# Altium schematic sheet Sheet4.SchDoc(Sheet4)
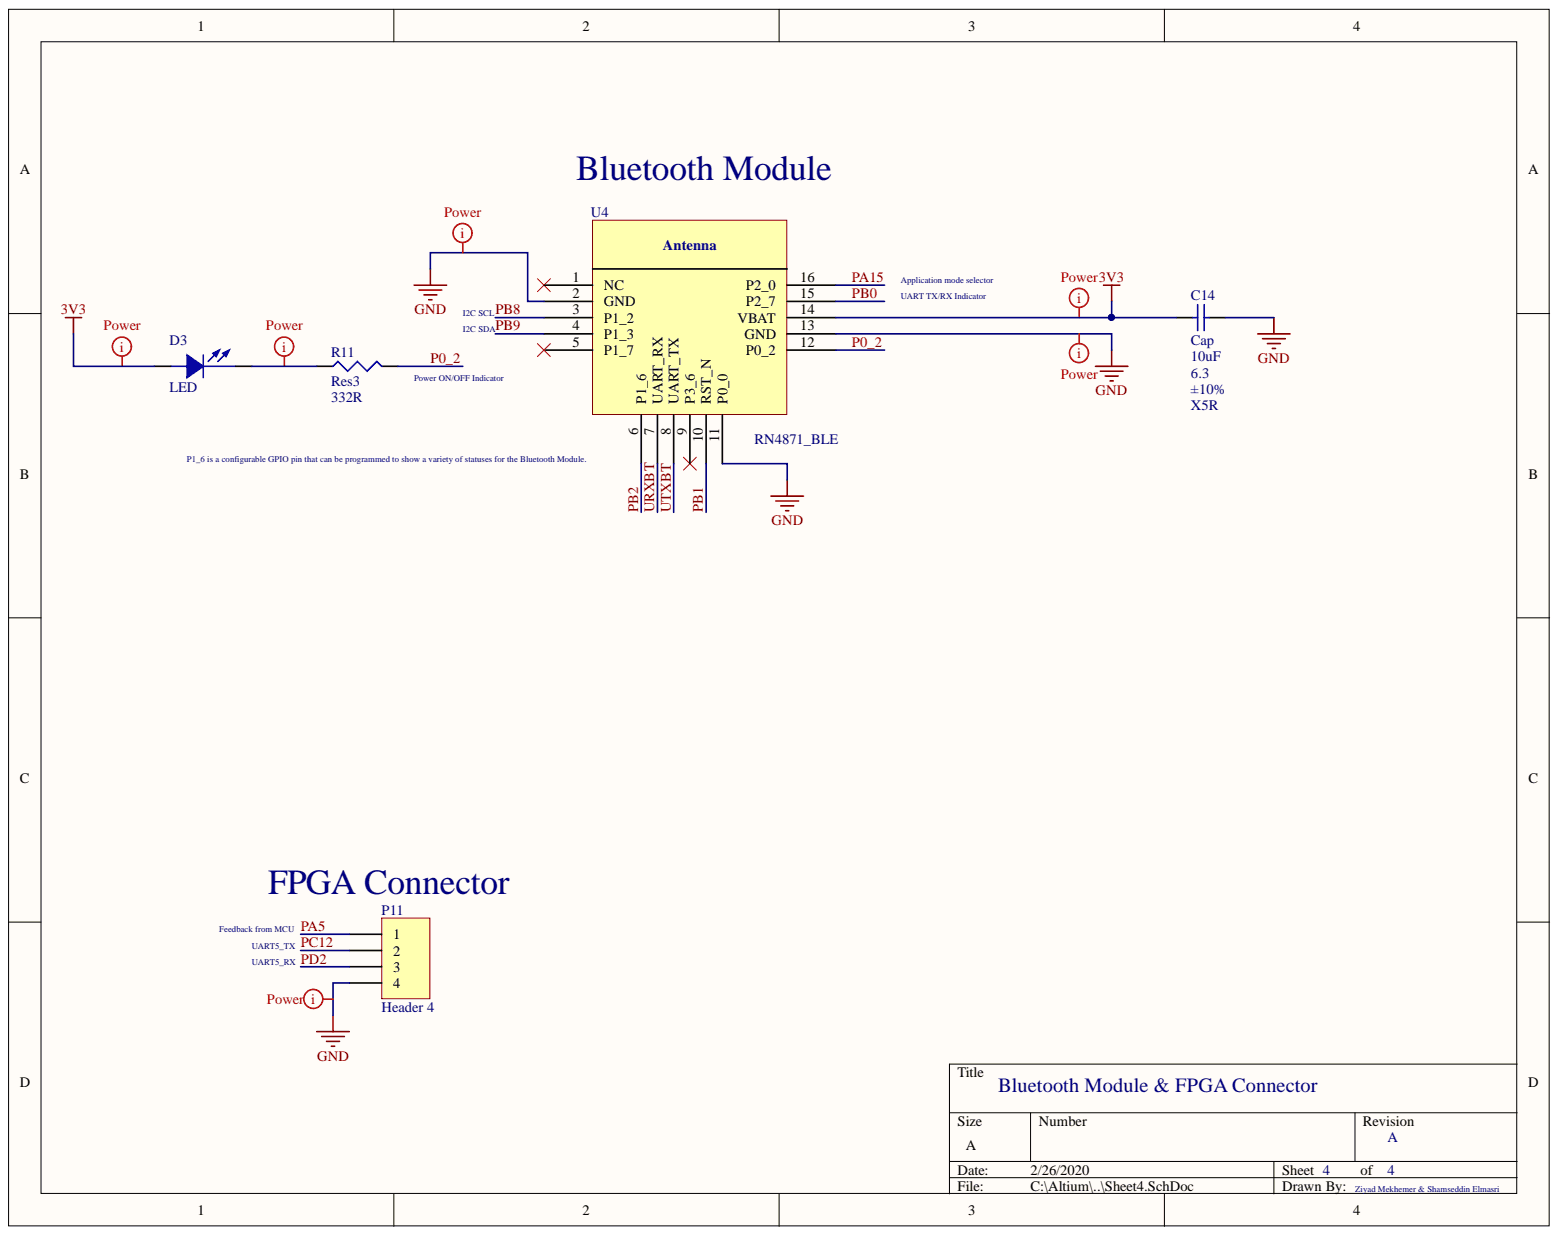
[diagram p0: Sheet4.SchDoc]

## Parts
C14  Cap  value 10uF  [CAPC2012X09M]
D3  LED  [LED]
P11  Header 4  [HDR1X4]
R11  Res3  value 332R  [RESC2112X05M]
U4  RN4871_BLE  [RN4871_BLE]

## Nets
3V3: C14.1 D3.1 U4.14(VBAT)
GND: C14.2 P11.4 U4.2(GND) U4.11(P0_0) U4.13(GND)
P0_2: R11.2 U4.12(P0_2)
PA5: P11.1
PA15: U4.16(P2_0)
PB0: U4.15(P2_7)
PB1: U4.10(RST_N)
PB2: U4.6(P1_6)
PB8: U4.3(P1_2)
PB9: U4.4(P1_3)
PC12: P11.2
PD2: P11.3
URXBT: U4.7(UART_RX)
UTXBT: U4.8(UART_TX)
NetD3_2: D3.2 R11.1

Not connected: U4 1(NC) 5(P1_7) 9(P3_6)

## Library data of the parts
C14 = CAP-X5R-10uF-6.3V-±10%: CAP CER 10uF 6.3v X5R 0805; MPN: C0805C106K9PACTU; WV: 6.3; Tolerance: ±10%; PN: 399-3138-1-ND; URL: http://www.digikey.ca/product-detail/en/C0805C106K9PACTU/399-3138-1-ND; Type: X5R; Name: CAP-X5R-10uF-6.3V-±10%; Library Name: esd_library.IntLib; Component Kind: Standard
P11 = Header 4: Header, 4-Pin Male; MPN: PEC04SFAN; PN: S1212E-04-ND; URL: http://www.digikey.ca/product-detail/en/PEC04SFAN/S1212E-04-ND; Library Name: esd_library.IntLib; Component Kind: Standard
R11 = Resistor_332R: RES SMD 332R OHM 1% 1/8W 0805; MPN: CRCW0805332RFKEA; PN: 541-332CCT-ND; URL: http://www.digikey.ca/product-detail/en/CRCW0805332RFKEA/541-332CCT-ND; Name: Resistor_332R; Library Name: esd_library.IntLib; Component Kind: Standard
U4 = RN4871_BLE: RN4870/71 BLE module by Microchip; Library Name: BT_Components.SchLib; ComponentLink3URL: http://ww1.microchip.com/downloads/en/DeviceDoc/RN4870-71-Bluetooth-Low-Energy-Module-User-Guide-DS50002466C.pdf; ComponentLink3Description: UserGuide; ComponentLink2URL: http://ww1.microchip.com/downloads/en/DeviceDoc/RN4870-71-Bluetooth-Low-Energy-Module-Data-Sheet-DS50002489D.pdf; ComponentLink2Description: Datasheet; ComponentLink1URL: https://www.digikey.ca/product-detail/en/microchip-technology/RN4871-I%2FRM128/RN4871-I%2FRM128-ND/8037765?utm_adgroup=RF%20Transceiver%20Modules&utm_source=google&utm_medium=cpc&utm_campaign=Shopping_RF%2FIF%20and%20RFID_NEW&utm_term=&productid=8037765; ComponentLink1Description: Digikey; Component Kind: Standard
D3 = LED: Library Name: esd_library.IntLib; Component Kind: Standard
